# Altium schematic sheet PCF8563.SchDoc
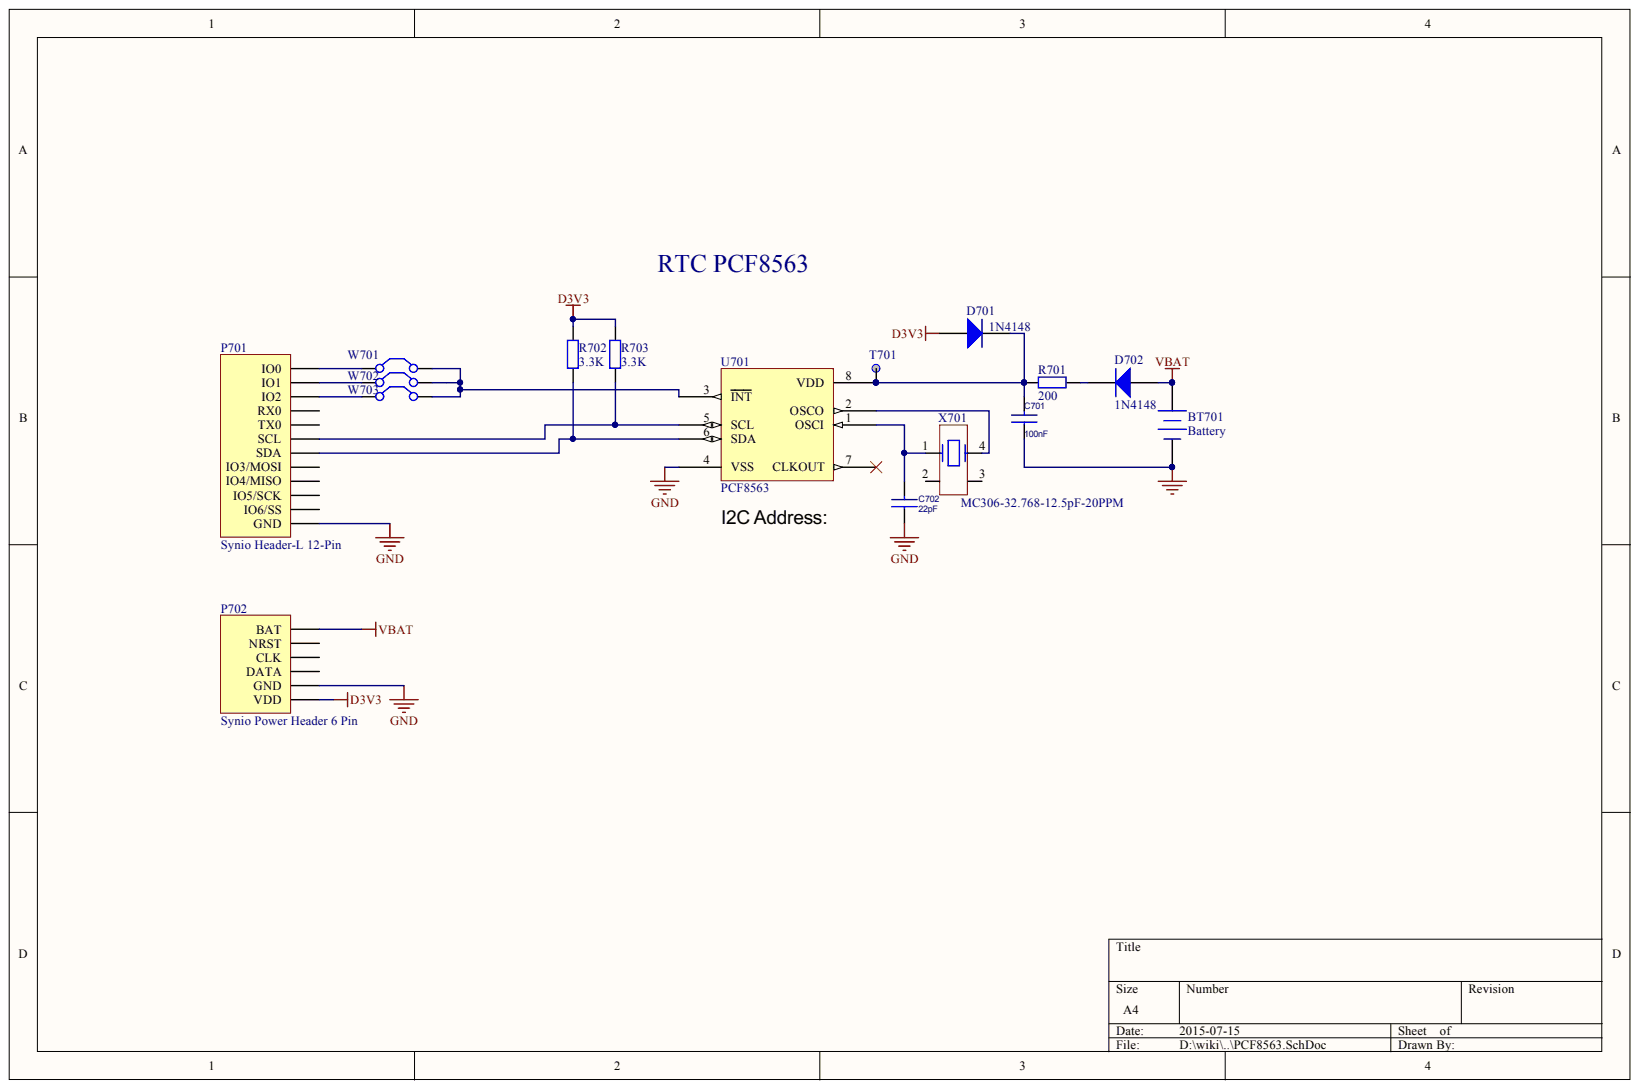
[diagram p0: PCF8563.SchDoc]

## Parts
BT701  Battery  [BAT CR1220]
C701  100nF  [C0603L]
C702  22pF  [C0603L]
D701  1N4148  [1206]
D702  1N4148  [1206]
P701  Synio Header-L 12-Pin  [HDR1X12]
P702  Synio Power Header 6 Pin  [HDR1X6/2.54MM]
R701  200  [R0603]
R702  3.3K  [R0603]
R703  3.3K  [R0603]
T701  TP  [TP]  (value not drawn)
U701  PCF8563  [SO8W_M]
W701  Jumper2-1  [HDR1X2/2.00MM]  (value not drawn)
W702  Jumper2-1  [HDR1X2/2.00MM]  (value not drawn)
W703  Jumper2-1  [HDR1X2/2.00MM]  (value not drawn)
X701  MC306-32.768-12.5pF-20PPM  [SM8]

## Nets
D3V3: D701.1 P702.1(VDD) R702.2 R703.2
GND: BT701.2 C701.2 C702.2 P701.1(GND) P702.2(GND) U701.4(VSS)
VBAT: BT701.1 D702.1 P702.6(BAT)
NetC701_1: C701.1 D701.2 R701.1 T701.1 U701.8(VDD)
NetC702_1: C702.1 U701.1(OSCI) X701.1
NetD702_2: D702.2 R701.2
NetP701_6: P701.6(SDA) R702.1 U701.6(SDA)
NetP701_7: P701.7(SCL) R703.1 U701.5(SCL)
NetP701_10: P701.10(IO2) W703.1
NetP701_11: P701.11(IO1) W702.1
NetP701_12: P701.12(IO0) W701.1
NetU701_2: U701.2(OSCO) X701.4
NetU701_3: U701.3(INT) W701.2 W702.2 W703.2

Not connected: P701 2(IO6/SS) 3(IO5/SCK) 4(IO4/MISO) 5(IO3/MOSI) 8(TX0) 9(RX0); P702 3(DATA) 4(CLK) 5(NRST); U701 7(CLKOUT); X701 2 3

## Library data of the parts
BT701 = Battery: Multicell Battery; Component Kind: Standard; LatestRevisionDate: 17-Jul-2002; LatestRevisionNote: Re-released for DXP Platform.; Library Name: Miscellaneous Devices.IntLib; PackageDescription: Battery; 2 Leads; PackageReference: BAT-2; Published: 24-Mar-1999; Publisher: Altium Limited
C701 C702 = CAP: 作者: 符观辉; Component Kind: Standard; Library Name: Mylib.SchLib
D701 D702 = Diode 1N4007: 1 Amp General Purpose Rectifier; Code_JEDEC: DO-204-AL; Component Kind: Standard; ComponentLink1Description: Manufacturer Link; ComponentLink1URL: http://www.fairchildsemi.com/; ComponentLink2Description: Datasheet; ComponentLink2URL: http://www.fairchildsemi.com/ds/1N%2F1N4001.pdf; DatasheetVersion: 1998; LatestRevisionDate: 17-Jul-2002; LatestRevisionNote: Re-released for DXP Platform.; Library Name: Misc.SchLib; PackageDescription: Diode, Axial; Body 5.2 x 2.7 mm (LxDia. max), Lead Dia. 0.86 mm (max); PackageReference: DO-41; PackageVersion: Aug-1999; Published: 8-Jun-2000; Signal Integrity: 1N4007; Simulation: 1N4007; Publisher: Altium Limited
P701 = Synio Header-L 12-Pin: Synio Header-L, 12-Pin; Component Kind: Standard; LatestRevisionDate: 17-Jul-2002; LatestRevisionNote: Re-released for DXP Platform.; Library Name: Connector.SchLib; Signal Integrity: Connector; Publisher: Altium Limited
R701-R703 = Res2: Resistor; Component Kind: Standard; LatestRevisionDate: 17-Jul-2002; LatestRevisionNote: Re-released for DXP Platform.; Library Name: Miscellaneous Devices.IntLib; PackageReference: AXIAL-0.4; Published: 8-Jun-2000; Signal Integrity: Res; Simulation: RESISTOR; Publisher: Altium Limited
  R701: Value: 200
  R702 R703: Value: 3.3K
T701 = TP: Test Point; Component Kind: Standard; Library Name: Misc.SchLib
U701 = PCF8563: Real Time Clock/Calendar; Component Kind: Standard; Library Name: Logic.SchLib
W701-W703 = Jumper2-1: Jumper Wire; Component Kind: Standard; LatestRevisionDate: 17-Jul-2002; LatestRevisionNote: Re-released for DXP Platform.; Library Name: Misc.SchLib; PackageReference: RAD-0.2; Published: 24-Mar-1999; Publisher: Altium Limited
X701 = MC306: Component Kind: Standard; Library Name: Misc.SchLib
P702 = Synio Power Header 6 Pin: Component Kind: Standard; Description: Synio Power Header 6 Pin; Library Name: Connector.SchLib
